# Altium schematic sheet Main DIP uC v1.2.SchDoc("Main DIP uC v1.2")
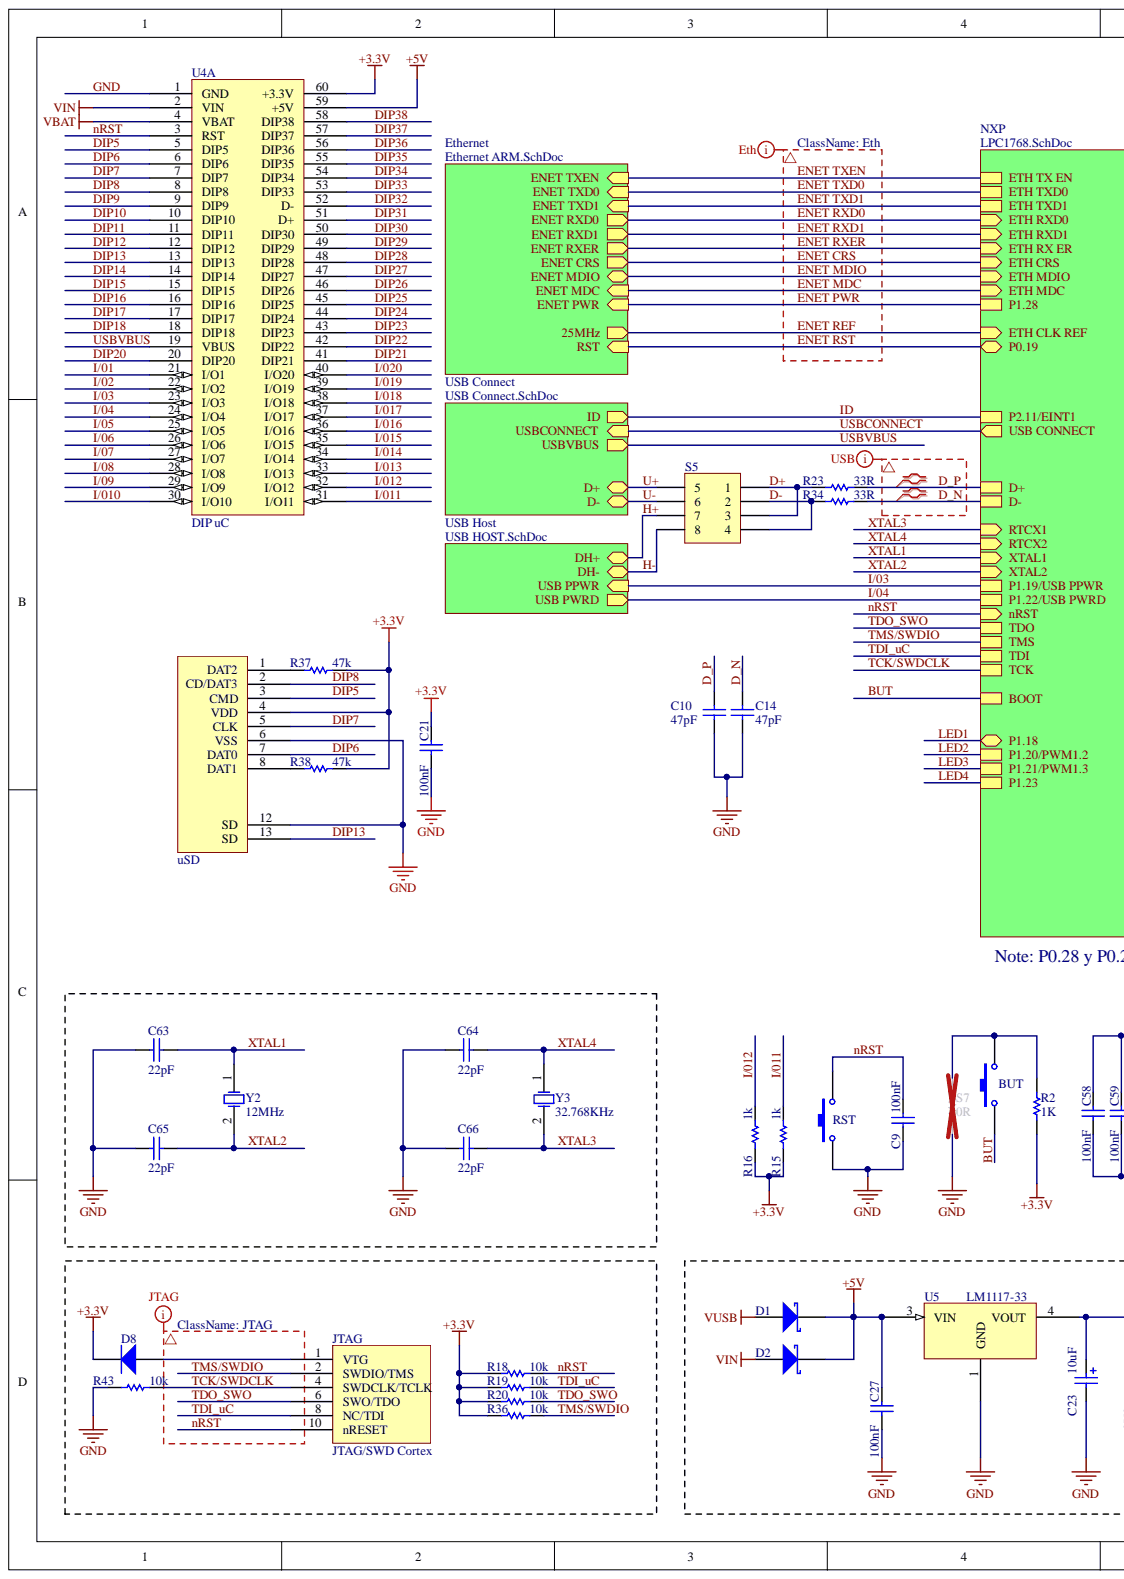
[diagram p0: Main DIP uC v1.2.SchDoc, left]
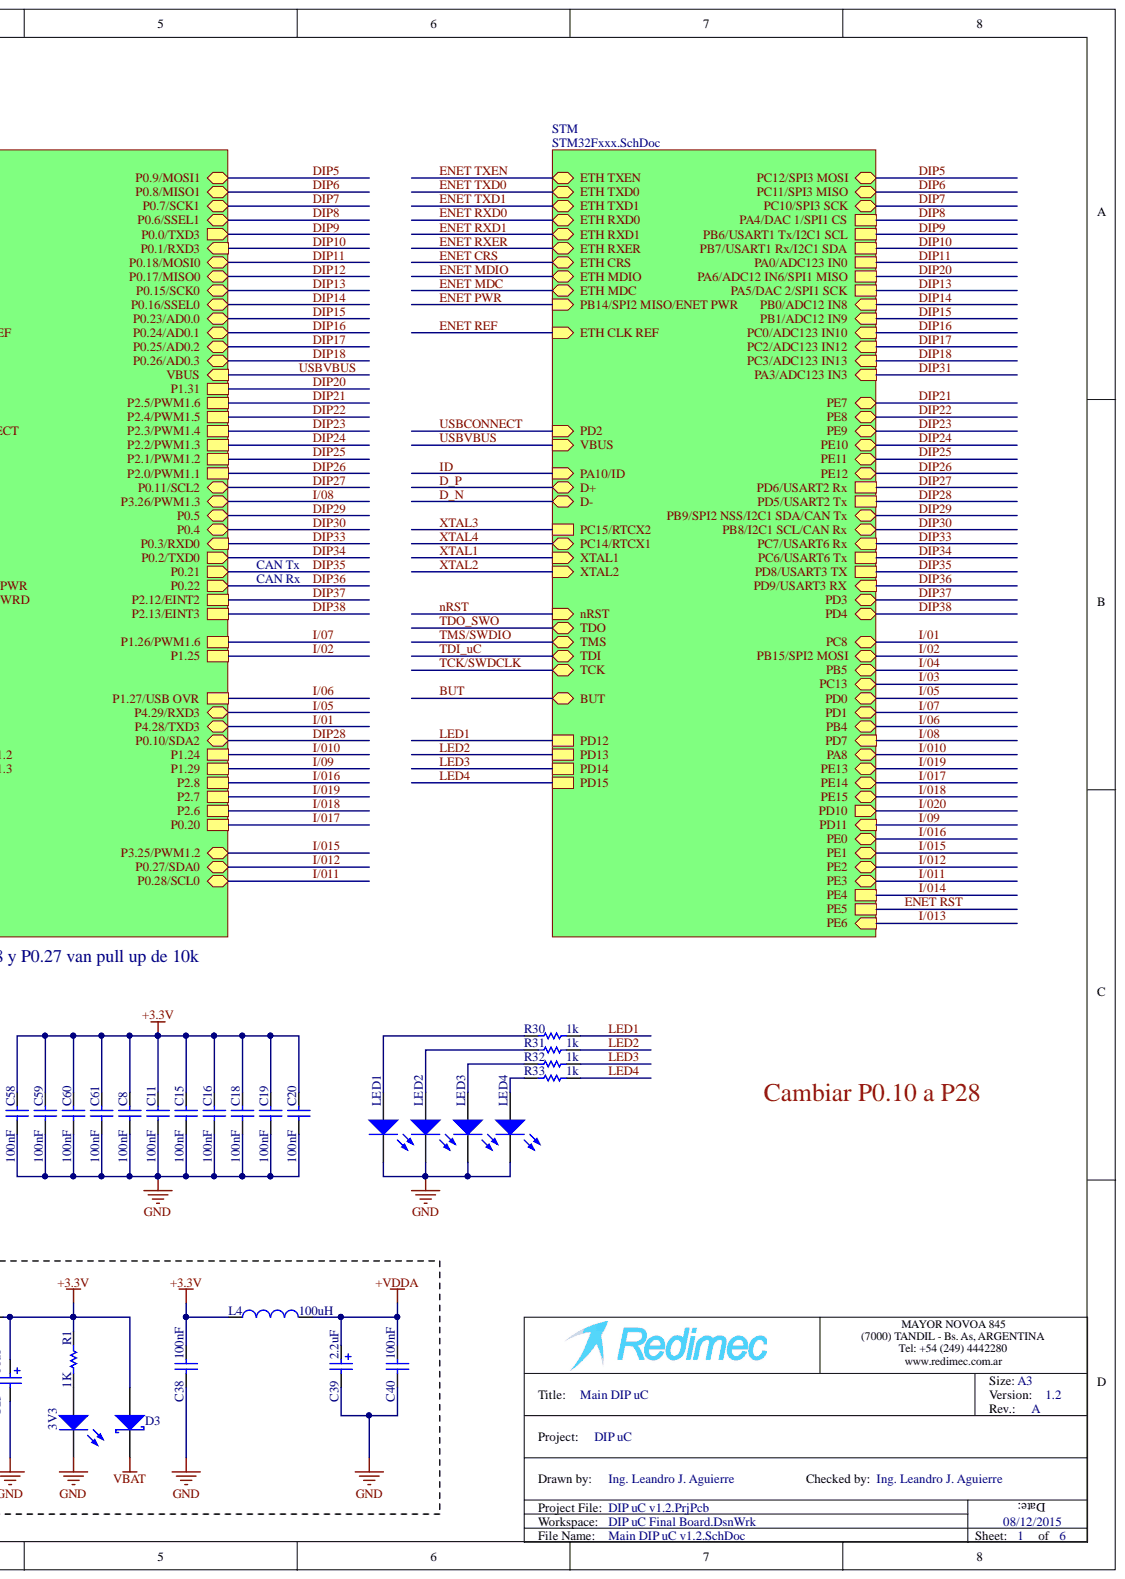
[diagram p1: Main DIP uC v1.2.SchDoc, right]

## Parts
3V3  3.3ON  [LED 0805]  (value not drawn)
BUT  BOT  [SPST-NO SMD]  (value not drawn)
C8  100nF  [0603c]
C9  100nF  [0603C]
C10  47pF  [0805]
C11  100nF  [0603c]
C14  47pF  [0805]
C15  100nF  [0603c]
C16  100nF  [0603c]
C18  100nF  [0603c]
C19  100nF  [0603c]
C20  100nF  [0603c]
C21  100nF  [0603c]
C23  10uF  [1206ct]
C27  100nF  [0603c]
C38  100nF  [0603c]
C39  2.2uF  [1206ct]
C40  100nF  [0603c]
C58  100nF  [0603c]
C59  100nF  [0603c]
C60  100nF  [0603c]
C61  100nF  [0603c]
C63  22pF  [0805]
C64  22pF  [0805]
C65  22pF  [0805]
C66  22pF  [0805]
D1  D Schottky  [SOD23]  (value not drawn)
D2  D Schottky  [SOD23]  (value not drawn)
D3  D Schottky  [SOD23]  (value not drawn)
D8  Diode 1N4001  [0805R]  (value not drawn)
JTAG  JTAG/SWD Cortex  [_HDR2X5]
L4  100uH  [1206L]
LED1  LED0  [LED 0805]  (value not drawn)
LED2  LED0  [LED 0805]  (value not drawn)
LED3  LED0  [LED 0805]  (value not drawn)
LED4  LED0  [LED 0805]  (value not drawn)
R1  1K  [0805r]
R2  1K  [0805r]
R15  1k  [0805r]
R16  1k  [0805r]
R18  10k  [0805r]
R19  10k  [0805r]
R20  10k  [0805r]
R23  33R  [0805r]
R30  1k  [0805r]
R31  1k  [0805r]
R32  1k  [0805r]
R33  1k  [0805r]
R34  33R  [0805r]
R36  10k  [0805r]
R37  47k  [0805r]
R38  47k  [0805r]
R43  10k  [0805r]
RST  RESET  [SPST-NO SMD]  (value not drawn)
S5  Header 4X2A  [HDR2X4_CEN]  (value not drawn)
S7  0R  [0603r]
U4  DIP uC  [uCDiscovery]  (part A)
U5  LM1117-33  [SOT223-4M]
uSD  uSD  [uSD]
Y2  12MHz  [XTAL]
Y3  32.768KHz  [XTAL 2]

## Nets
+3.3V: C8.2 C11.1 C15.2 C16.2 C18.2 C19.2 C20.2 C21.2 C23.1 C38.2 C58.2 C59.2 C60.2 C61.2 D3.1 D8.2 L4.1 R1.1 R2.2 R15.2 R16.2 R18.2 R19.2 R20.2 R36.2 R37.2 R38.2 U4.60(+3.3V) U5.4 uSD.4(VDD)
+5V: C27.2 D1.2 D2.2 U4.59(+5V) U5.3
+VDDA: C39.1 C40.2 L4.2
VBAT: D3.2 U4.4(VBAT)
VIN: D2.1 U4.2(VIN)
VUSB: D1.1
BUT: BUT.1
D+: R23.1 S5.1 S5.3
D-: R34.1 S5.2 S5.4
D_N: C14.2 R34.2
D_P: C10.2 R23.2
DIP5: U4.5(DIP5) uSD.3(CMD)
DIP6: U4.6(DIP6) uSD.7(DAT0)
DIP7: U4.7(DIP7) uSD.5(CLK)
DIP8: U4.8(DIP8) uSD.2(CD/DAT3)
DIP9: U4.9(DIP9)
DIP10: U4.10(DIP10)
DIP11: U4.11(DIP11)
DIP12: U4.12(DIP12)
DIP13: U4.13(DIP13) uSD.13(SD)
DIP14: U4.14(DIP14)
DIP15: U4.15(DIP15)
DIP16: U4.16(DIP16)
DIP17: U4.17(DIP17)
DIP18: U4.18(DIP18)
DIP20: U4.20(DIP20)
DIP21: U4.41(DIP21)
DIP22: U4.42(DIP22)
DIP23: U4.43(DIP23)
DIP24: U4.44(DIP24)
DIP25: U4.45(DIP25)
DIP26: U4.46(DIP26)
DIP27: U4.47(DIP27)
DIP28: U4.48(DIP28)
DIP29: U4.49(DIP29)
DIP30: U4.50(DIP30)
DIP31: U4.51(D+)
DIP32: U4.52(D-)
DIP33: U4.53(DIP33)
DIP34: U4.54(DIP34)
DIP35: U4.55(DIP35)
DIP36: U4.56(DIP36)
DIP37: U4.57(DIP37)
DIP38: U4.58(DIP38)
GND: 3V3.2 C8.1 C9.2 C10.1 C11.2 C14.1 C15.1 C16.1 C18.1 C19.1 C20.1 C21.1 C23.2 C27.1 C38.1 C39.2 C40.1 C58.1 C59.1 C60.1 C61.1 C63.1 C64.1 C65.1 C66.1 JTAG.3 JTAG.5 JTAG.9 LED1.2 LED2.2 LED3.2 LED4.2 R43.1 RST.1 S7.2 U4.1(GND) U5.1 uSD.6(VSS) uSD.12(SD)
H+: S5.7
H-: S5.8
I/01: U4.21(I/O1)
I/02: U4.22(I/O2)
I/03: U4.23(I/O3)
I/04: U4.24(I/O4)
I/05: U4.25(I/O5)
I/06: U4.26(I/O6)
I/07: U4.27(I/O7)
I/08: U4.28(I/O8)
I/09: U4.29(I/O9)
I/010: U4.30(I/O10)
I/011: R15.1 U4.31(I/O11)
I/012: R16.1 U4.32(I/O12)
I/013: U4.33(I/O13)
I/014: U4.34(I/O14)
I/015: U4.35(I/O15)
I/016: U4.36(I/O16)
I/017: U4.37(I/O17)
I/018: U4.38(I/O18)
I/019: U4.39(I/O19)
I/020: U4.40(I/O20)
LED1: R30.1
LED2: R31.1
LED3: R32.1
LED4: R33.1
nRST: C9.1 JTAG.10(nRESET) R18.1 RST.2 U4.3(RST)
TCK/SWDCLK: JTAG.4 R43.2
TDI_uC: JTAG.8(NC/TDI) R19.1
TDO_SWO: JTAG.6(SWO/TDO) R20.1
TMS/SWDIO: JTAG.2(SWDIO/TMS) R36.1
U+: S5.5
U-: S5.6
USBVBUS: U4.19(VBUS)
XTAL1: C63.2 Y2.1
XTAL2: C65.2 Y2.2
XTAL3: C66.2 Y3.2
XTAL4: C64.2 Y3.1
Net3V3_1: 3V3.1 R1.2
NetBUT_2: BUT.2 R2.1 S7.1
NetD8_1: D8.1 JTAG.1(VTG)
NetLED1_1: LED1.1 R30.2
NetLED2_1: LED2.1 R31.2
NetLED3_1: LED3.1 R32.2
NetLED4_1: LED4.1 R33.2
NetR37_1: R37.1 uSD.1(DAT2)
NetR38_1: R38.1 uSD.8(DAT1)
ENET CRS:
ENET MDC:
ENET MDIO:
ENET PWR:
ENET REF:
ENET RST:
ENET RXD0:
ENET RXD1:
ENET RXER:
ENET TXD0:
ENET TXD1:
ENET TXEN:
ID:
N00214:
N00215:
N00216:
USBCONNECT:

## Library data of the parts
3V3 = LED3: Typical BLUE SiC LED; Revision 2: 17-Dec-2003: PCB Footprint replaced.; Revision 1: Jul-2002: Re-released for DXP Platform.; Simulation: LED3; Published: 8-Jun-2000; Library Name: Miscellaneous Devices.IntLib; Component Kind: Standard; Publisher: Altium Limited
BUT RST = SW-PB: Switch; Published: 24-Mar-1999; PackageReference: SPST-2; Library Name: Miscellaneous Devices.IntLib; LatestRevisionNote: Re-released for DXP Platform.; LatestRevisionDate: 17-Jul-2002; Component Kind: Standard; Publisher: Altium Limited
C8-C11 C14-C16 C18-C21 C27 C38 C40 C58-C61 C64-C66 = Cap: Capacitor; Simulation: CAP; Signal Integrity: Cap; Published: 8-Jun-2000; Library Name: Miscellaneous Devices.IntLib; Component Kind: Standard; Publisher: Altium Limited
  C8 C11 C15 C16 C18-C21 C27 C38 C40 C58-C61: Value: 100nF; PackageReference: RAD-0.3; LatestRevisionNote: Re-released for DXP Platform.; LatestRevisionDate: 17-Jul-2002
  C9: Value: 100nF; Revision: July-2002: Re-released for DXP Platform.
  C10 C14: Value: 47pF; PackageReference: RAD-0.3; LatestRevisionNote: Re-released for DXP Platform.; LatestRevisionDate: 17-Jul-2002
  C64-C66: Value: 22pF; PackageReference: RAD-0.3; LatestRevisionNote: Re-released for DXP Platform.; LatestRevisionDate: 17-Jul-2002
C23 C39 = Cap Pol2: Polarized Capacitor (Axial); P/N: T491A106M016AT; Simulation: CAP; Signal Integrity: Cap Pol; Published: 8-Jun-2000; PackageReference: POLAR0.8; Library Name: Miscellaneous Devices.IntLib; LatestRevisionNote: Re-released for DXP Platform.; LatestRevisionDate: 17-Jul-2002; Component Kind: Standard; Publisher: Altium Limited
  C23: Value: 10uF
  C39: Value: 2.2uF
C63 = Cap: Value: 22pF; Simulation: CAP; Signal Integrity: Cap; Published: 8-Jun-2000; PackageReference: RAD-0.3; Library Name: Miscellaneous Devices.IntLib; LatestRevisionNote: Re-released for DXP Platform.; LatestRevisionDate: 17-Jul-2002; Component Kind: Standard; Publisher: Altium Limited
D1-D3 = D Schottky: Schottky Diode; Simulation: SKYDIODE; Signal Integrity: D Schottky; Published: 8-Jun-2000; PackageReference: SMB; Library Name: Miscellaneous Devices.IntLib; LatestRevisionNote: Re-released for DXP Platform.; LatestRevisionDate: 17-Jul-2002; Component Kind: Standard; Code_JEDEC: DO-214-AA; Publisher: Altium Limited
D8 = Diode 1N4001: 1 Amp General Purpose Rectifier; Simulation: 1N4001; Signal Integrity: 1N4001; Published: 8-Jun-2000; PackageVersion: Aug-1999; PackageReference: DO-41; Library Name: Miscellaneous Devices.IntLib; LatestRevisionNote: Re-released for DXP Platform.; LatestRevisionDate: 17-Jul-2002; DatasheetVersion: 1998; ComponentLink2URL: http://www.fairchildsemi.com/ds/1N%2F1N4001.pdf; ComponentLink2Description: Datasheet; ComponentLink1URL: http://www.fairchildsemi.com/; ComponentLink1Description: Manufacturer Link; Component Kind: Standard; Code_JEDEC: DO-204-AL; Publisher: Altium Limited
L4 = Inductor: Code_IEC: 1005; Value: 100uH; Simulation: INDUCTOR; Signal Integrity: Inductor; Published: 8-Jun-2000; PackageReference: 0402-A; PackageDescription: Chip Inductor; Library Name: Miscellaneous Devices.IntLib; LatestRevisionNote: IPC-7351 Footprint Added.; LatestRevisionDate: 29-May-2009; Description: Inductor; Component Kind: Standard; Code_JEITA: 0402; Publisher: Altium Limited
LED1-LED4 = LED0: Typical INFRARED GaAs LED; Simulation: LED0; Published: 8-Jun-2000; PackageReference: LED-0; Library Name: Miscellaneous Devices.IntLib; LatestRevisionNote: Mech Layer 1 removed.; LatestRevisionDate: 08-Jul-2005; Component Kind: Standard; Publisher: Altium Limited
R1 R2 R15 R16 R18-R20 R23 R30-R34 R36-R38 R43 S7 = Res1: Resistor; Simulation: RESISTOR; Signal Integrity: Res; Published: 8-Jun-2000; Library Name: Miscellaneous Devices.IntLib; Component Kind: Standard; Publisher: Altium Limited
  R1 R2: Value: 1K; Revision: July-2002: Re-released for DXP Platform.
  R15 R16 R30-R33: Value: 1k; PackageReference: AXIAL-0.3; LatestRevisionNote: Re-released for DXP Platform.; LatestRevisionDate: 17-Jul-2002
  R18-R20 R36: Value: 10k; PackageReference: AXIAL-0.3; LatestRevisionNote: Re-released for DXP Platform.; LatestRevisionDate: 17-Jul-2002
  R23 R34: Value: 33R; PackageReference: AXIAL-0.3; LatestRevisionNote: Re-released for DXP Platform.; LatestRevisionDate: 17-Jul-2002
  R37 R38: Value: 47k; PackageReference: AXIAL-0.3; LatestRevisionNote: Re-released for DXP Platform.; LatestRevisionDate: 17-Jul-2002
  R43: Value: 10k; Revision: July-2002: Re-released for DXP Platform.
  S7: Value: 0R; Revision: July-2002: Re-released for DXP Platform.
S5 = Header 4X2A: Header, 4-Pin, Dual row; Signal Integrity: Connector; Library Name: Miscellaneous Connectors.IntLib; LatestRevisionNote: Re-released for DXP Platform.; LatestRevisionDate: 17-Jul-2002; Component Kind: Standard; Publisher: Altium Limited
U5 = LM1117-XX: Value: LM1117-33; Library Name: Integrados.SchLib; Component Kind: Standard
uSD = uSD: P/N: DM3CS; Library Name: Integrados.SchLib; Component Kind: Standard
Y2 = XTAL: Crystal Oscillator; Value: ABM2-12.000MHZ-D4Y-T; Simulation: XTAL; Revision: July-2002: Re-released for DXP Platform.; Published: 8-Jun-2000; Library Name: Miscellaneous Devices.IntLib; Component Kind: Standard; Publisher: Altium Limited
Y3 = XTAL: Crystal Oscillator; Simulation: XTAL; Revision: July-2002: Re-released for DXP Platform.; Published: 8-Jun-2000; Library Name: Miscellaneous Devices.IntLib; Component Kind: Standard; Publisher: Altium Limited
JTAG = JTAG/SWD Cortex: Library Name: Conectores.SchLib; Component Kind: Standard
U4 = DIP uC: P/N: DIP uC; Component Kind: Standard; Library Name: Specific Applications.SchLib
